# Altium schematic sheet AT2560.SchDoc(AT2560)
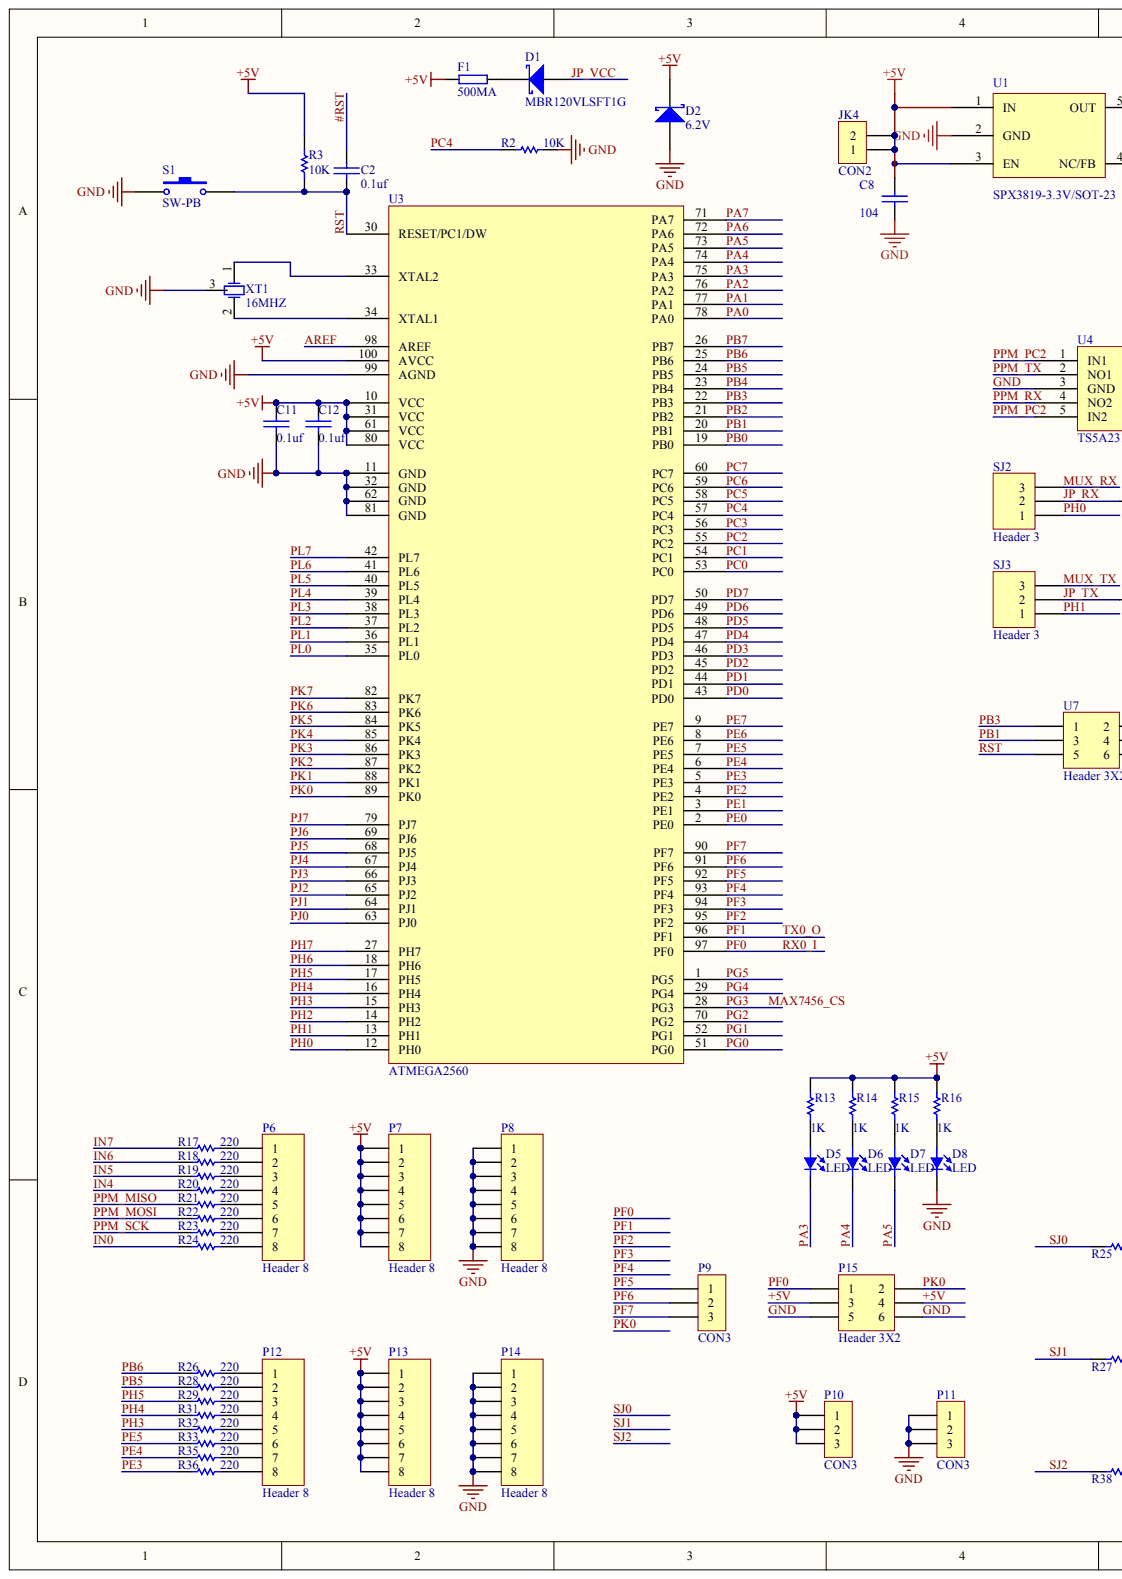
[diagram p0: AT2560.SchDoc, left]
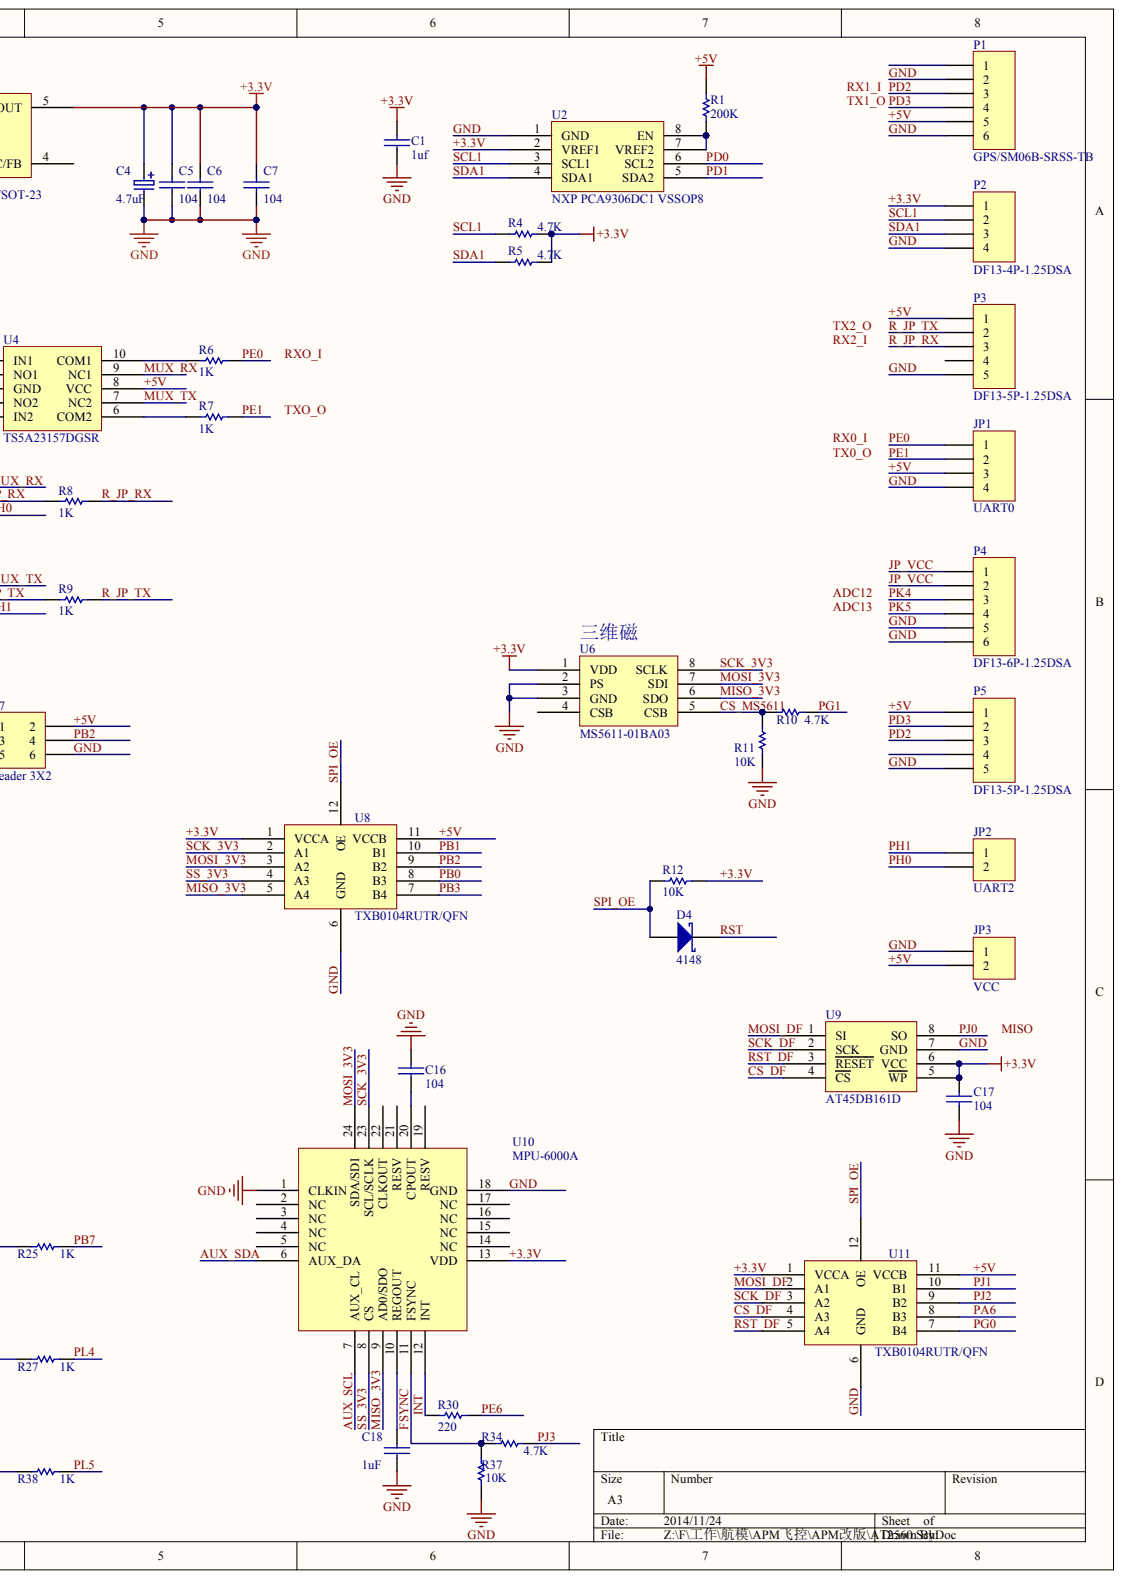
[diagram p1: AT2560.SchDoc, right]

## Parts
C1  1uf
C2  0.1uf
C4  4.7uF
C5  104
C6  104
C7  104
C8  104
C11  0.1uf
C12  0.1uf
C16  104
C17  104
C18  1uF
D1  MBR120VLSFT1G
D2  6.2V
D4  4148
D5  LED
D6  LED
D7  LED
D8  LED
F1  500MA
JK4  CON2
JP1  UART0
JP2  UART2
JP3  VCC
P1  GPS/SM06B-SRSS-TB
P2  DF13-4P-1.25DSA
P3  DF13-5P-1.25DSA
P4  DF13-6P-1.25DSA
P5  DF13-5P-1.25DSA
P6  Header 8
P7  Header 8
P8  Header 8
P9  CON3
P10  CON3
P11  CON3
P12  Header 8
P13  Header 8
P14  Header 8
P15  Header 3X2
R1  200K
R2  10K
R3  10K
R4  4.7K
R5  4.7K
R6  1K
R7  1K
R8  1K
R9  1K
R10  4.7K
R11  10K
R12  10K
R13  1K
R14  1K
R15  1K
R16  1K
R17  220
R18  220
R19  220
R20  220
R21  220
R22  220
R23  220
R24  220
R25  1K
R26  220
R27  1K
R28  220
R29  220
R30  220
R31  220
R32  220
R33  220
R34  4.7K
R35  220
R36  220
R37  10K
R38  1K
S1  SW-PB
SJ2  Header 3
SJ3  Header 3
U1  SPX3819-3.3V/SOT-23
U2  NXP PCA9306DC1 VSSOP8
U3  ATMEGA2560
U4  TS5A23157DGSR
U6  MS5611-01BA03
U7  Header 3X2
U8  TXB0104RUTR/QFN
U9  AT45DB161D
U10  MPU-6000A
U11  TXB0104RUTR/QFN
XT1  16MHZ

## Nets
#RST: C2.1
+3.3V: C1.2 C4.1 C5.1 C6.1 C7.1 C17.2 P2.1 R4.1 R5.2 R12.1 U1.5(OUT) U2.2(VREF1) U6.1(VDD) U8.1(VCCA) U9.5(WP) U9.6(VCC) U10.13(VDD) U11.1(VCCA)
+5V: C8.1 C11.1 C12.1 D2.3 F1.2 JK4.1 JK4.2 JP1.3 JP3.2 P1.5 P3.1 P5.1 P7.1 P7.2 P7.3 P7.4 P7.5 P7.6 P7.7 P7.8 P10.1 P10.2 P10.3 P13.1 P13.2 P13.3 P13.4 P13.5 P13.6 P13.7 P13.8 P15.3 P15.4 R1.2 R3.2 R13.2 R14.2 R15.2 R16.2 U1.1(IN) U1.3(EN) U3.10(VCC) U3.31(VCC) U3.61(VCC) U3.80(VCC) U3.100(AVCC) U4.8(VCC) U7.2 U8.11(VCCB) U11.11(VCCB)
AREF: U3.98(AREF)
AUX_SCL: U10.7(AUX_CL)
AUX_SDA: U10.6(AUX_DA)
CS_DF: U9.4(CS) U11.4(A3)
CS_MS5611: R10.2 R11.2 U6.5(CSB)
FSYNC: R34.2 R37.2 U10.11(FSYNC)
GND: C1.1 C4.2 C5.2 C6.2 C7.2 C8.2 C11.2 C12.2 C16.2 C17.1 C18.2 D2.1 D8.2 JP1.4 JP3.1 P1.2 P1.6 P2.4 P3.5 P4.5 P4.6 P5.5 P8.1 P8.2 P8.3 P8.4 P8.5 P8.6 P8.7 P8.8 P11.1 P11.2 P11.3 P14.1 P14.2 P14.3 P14.4 P14.5 P14.6 P14.7 P14.8 P15.5 P15.6 R2.1 R11.1 R37.1 S1.1 U1.2(GND) U2.1(GND) U3.11(GND) U3.32(GND) U3.62(GND) U3.81(GND) U3.99(AGND) U4.3(GND) U6.2(PS) U6.3(GND) U7.6 U8.6(GND) U9.7(GND) U10.1(CLKIN) U10.18(GND) U11.6(GND) XT1.3
IN0: R24.2
IN4: R20.2
IN5: R19.2
IN6: R18.2
IN7: R17.2
INT: R30.2 U10.12(INT)
JP_RX: R8.1 SJ2.2
JP_TX: R9.1 SJ3.2
JP_VCC: D1.1 P4.1 P4.2
MAX7456_CS: U3.28(PG3)
MISO_3V3: U6.6(SDO) U8.5(A4) U10.9(AD0/SDO)
MOSI_3V3: U6.7(SDI) U8.3(A2) U10.24(SDA/SDI)
MOSI_DF: U9.1(SI) U11.2(A1)
MUX_RX: SJ2.3 U4.9(NC1)
MUX_TX: SJ3.3 U4.7(NC2)
PA0: U3.78(PA0)
PA1: U3.77(PA1)
PA2: U3.76(PA2)
PA3: D5.2 U3.75(PA3)
PA4: D6.2 U3.74(PA4)
PA5: D7.2 U3.73(PA5)
PA6: U3.72(PA6) U11.8(B3)
PA7: U3.71(PA7)
PB0: U3.19(PB0) U8.8(B3)
PB1: U3.20(PB1) U7.3 U8.10(B1)
PB2: U3.21(PB2) U7.4 U8.9(B2)
PB3: U3.22(PB3) U7.1 U8.7(B4)
PB4: U3.23(PB4)
PB5: R28.2 U3.24(PB5)
PB6: R26.2 U3.25(PB6)
PB7: R25.1 U3.26(PB7)
PC0: U3.53(PC0)
PC1: U3.54(PC1)
PC2: U3.55(PC2)
PC3: U3.56(PC3)
PC4: R2.2 U3.57(PC4)
PC5: U3.58(PC5)
PC6: U3.59(PC6)
PC7: U3.60(PC7)
PD0: U2.6(SCL2) U3.43(PD0)
PD1: U2.5(SDA2) U3.44(PD1)
PD2: P1.3 P5.3 U3.45(PD2)
PD3: P1.4 P5.2 U3.46(PD3)
PD4: U3.47(PD4)
PD5: U3.48(PD5)
PD6: U3.49(PD6)
PD7: U3.50(PD7)
PE0: JP1.1 R6.2 U3.2(PE0)
PE1: JP1.2 R7.2 U3.3(PE1)
PE2: U3.4(PE2)
PE3: R36.2 U3.5(PE3)
PE4: R35.2 U3.6(PE4)
PE5: R33.2 U3.7(PE5)
PE6: R30.1 U3.8(PE6)
PE7: U3.9(PE7)
PF0: P15.1 U3.97(PF0)
PF1: U3.96(PF1)
PF2: U3.95(PF2)
PF3: U3.94(PF3)
PF4: U3.93(PF4)
PF5: P9.1 U3.92(PF5)
PF6: P9.2 U3.91(PF6)
PF7: P9.3 U3.90(PF7)
PG0: U3.51(PG0) U11.7(B4)
PG1: R10.1 U3.52(PG1)
PG2: U3.70(PG2)
PG4: U3.29(PG4)
PG5: U3.1(PG5)
PH0: JP2.2 SJ2.1 U3.12(PH0)
PH1: JP2.1 SJ3.1 U3.13(PH1)
PH2: U3.14(PH2)
PH3: R32.2 U3.15(PH3)
PH4: R31.2 U3.16(PH4)
PH5: R29.2 U3.17(PH5)
PH6: U3.18(PH6)
PH7: U3.27(PH7)
PJ0: U3.63(PJ0) U9.8(SO)
PJ1: U3.64(PJ1) U11.10(B1)
PJ2: U3.65(PJ2) U11.9(B2)
PJ3: R34.1 U3.66(PJ3)
PJ4: U3.67(PJ4)
PJ5: U3.68(PJ5)
PJ6: U3.69(PJ6)
PJ7: U3.79(PJ7)
PK0: P15.2 U3.89(PK0)
PK1: U3.88(PK1)
PK2: U3.87(PK2)
PK3: U3.86(PK3)
PK4: P4.3 U3.85(PK4)
PK5: P4.4 U3.84(PK5)
PK6: U3.83(PK6)
PK7: U3.82(PK7)
PL0: U3.35(PL0)
PL1: U3.36(PL1)
PL2: U3.37(PL2)
PL3: U3.38(PL3)
PL4: R27.1 U3.39(PL4)
PL5: R38.1 U3.40(PL5)
PL6: U3.41(PL6)
PL7: U3.42(PL7)
PPM_MISO: R21.2
PPM_MOSI: R22.2
PPM_PC2: U4.1(IN1) U4.5(IN2)
PPM_RX: U4.4(NO2)
PPM_SCK: R23.2
PPM_TX: U4.2(NO1)
R_JP_RX: P3.3 R8.2
R_JP_TX: P3.2 R9.2
RST: C2.2 D4.3 R3.1 S1.2 U3.30(RESET/PC1/DW) U7.5
RST_DF: U9.3(RESET) U11.5(A4)
SCK_3V3: U6.8(SCLK) U8.2(A1) U10.23(SCL/SCLK)
SCK_DF: U9.2(SCK) U11.3(A2)
SCL1: P2.2 R4.2 U2.3(SCL1)
SDA1: P2.3 R5.1 U2.4(SDA1)
SJ0: R25.2
SJ1: R27.2
SJ2: R38.2
SPI_OE: D4.1 R12.2 U8.12(OE) U11.12(OE)
SS_3V3: U8.4(A3) U10.8(CS)
NetC16_1: C16.1 U10.20(CPOUT)
NetC18_1: C18.1 U10.10(REGOUT)
NetD1_3: D1.3 F1.1
NetD5_1: D5.1 R13.1
NetD6_1: D6.1 R14.1
NetD7_1: D7.1 R15.1
NetD8_1: D8.1 R16.1
NetP6_1: P6.1 R17.1
NetP6_2: P6.2 R18.1
NetP6_3: P6.3 R19.1
NetP6_4: P6.4 R20.1
NetP6_5: P6.5 R21.1
NetP6_6: P6.6 R22.1
NetP6_7: P6.7 R23.1
NetP6_8: P6.8 R24.1
NetP12_1: P12.1 R26.1
NetP12_2: P12.2 R28.1
NetP12_3: P12.3 R29.1
NetP12_4: P12.4 R31.1
NetP12_5: P12.5 R32.1
NetP12_6: P12.6 R33.1
NetP12_7: P12.7 R35.1
NetP12_8: P12.8 R36.1
NetR1_1: R1.1 U2.7(VREF2) U2.8(EN)
NetR6_1: R6.1 U4.10(COM1)
NetR7_1: R7.1 U4.6(COM2)
NetU3_33: U3.33(XTAL2) XT1.1
NetU3_34: U3.34(XTAL1) XT1.2
ADC12:
ADC13:
MISO:
RX1_I:
RX2_I:
RXO_I:
TX1_O:
TX2_O:
TXO_O:

Not connected: P1 1; P3 4; P5 4; U1 4(NC/FB); U6 4(CSB); U10 2(NC) 3(NC) 4(NC) 5(NC) 14(NC) 15(NC) 16(NC) 17(NC) 19(RESV) 21(RESV) 22(CLKOUT)
